# Altium schematic sheet ECU_MCU.SchDoc
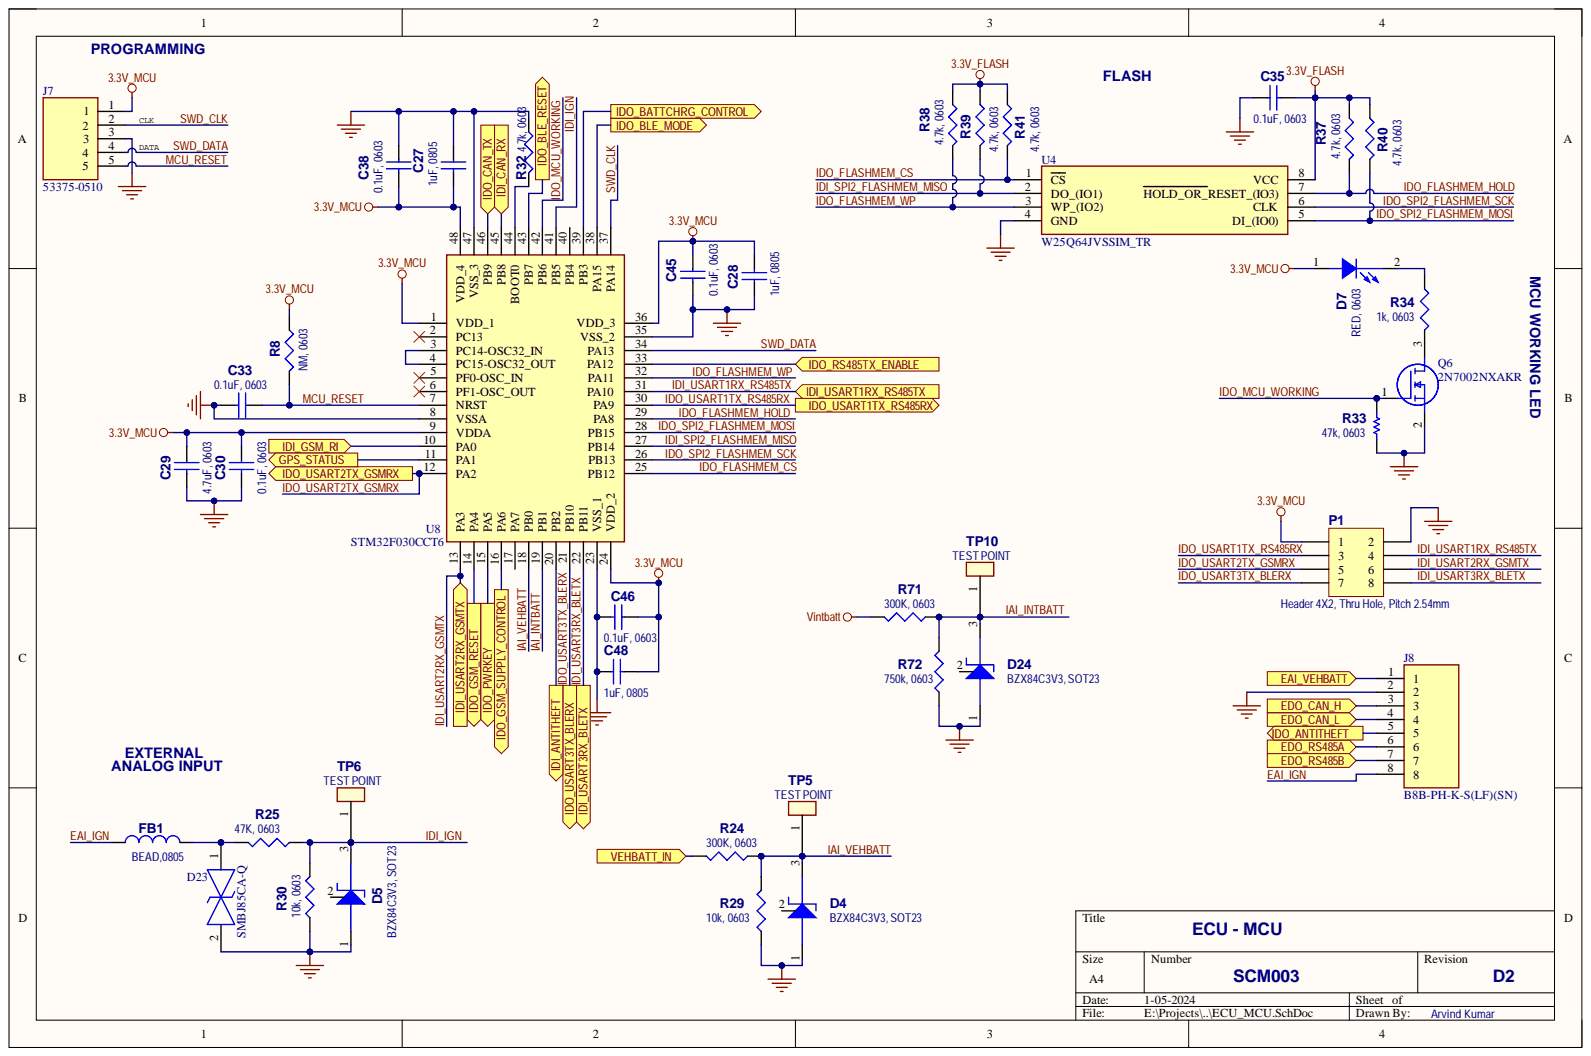
[diagram p0: ECU_MCU.SchDoc]

## Parts
C27  1uF, 0805  [C0805]
C28  1uF, 0805  [C0805]
C29  4.7uF, 0603  [C0603]
C30  0.1uF, 0603  [C0603]
C33  0.1uF, 0603  [C0603]
C35  0.1uF, 0603  [C0603]
C38  0.1uF, 0603  [C0603]
C45  0.1uF, 0603  [C0603]
C46  0.1uF, 0603  [C0603]
C48  1uF, 0805  [C0805]
D4  BZX84C3V3, SOT23  [BZX84C3V3-E3-08]
D5  BZX84C3V3, SOT23  [BZX84C3V3-E3-08]
D7  RED, 0603  [L0603]
D23  SMBJ85CA-Q  [DIONM5436X244N]
D24  BZX84C3V3, SOT23  [BZX84C3V3-E3-08]
FB1  BEAD,0805  [C0805]
J7  53375-0510  [53375-0510]
J8  B8B-PH-K-S(LF)(SN)  [SHDR8W50P0X200_1X8_1800X470X630P]
P1  Header 4X2, Thru Hole, Pitch 2.54mm  [HDR2X4]
Q6  2N7002NXAKR  [SOT95P230X110-3N]
R8  NM, 0603  [R0603]
R24  300K, 0603  [R0603]
R25  47K, 0603  [R0603]
R29  10k, 0603  [R0603]
R30  10k, 0603  [R0603]
R32  4.7k, 0603  [R0603]
R33  47k, 0603  [R0603]
R34  1k, 0603  [R0603]
R37  4.7k, 0603  [R0603]
R38  4.7k, 0603  [R0603]
R39  4.7k, 0603  [R0603]
R40  4.7k, 0603  [R0603]
R41  4.7k, 0603  [R0603]
R71  300K, 0603  [R0603]
R72  750k, 0603  [R0603]
TP5  TEST POINT  [Test Point SMD 1.5mm]
TP6  TEST POINT  [Test Point SMD 1.5mm]
TP10  TEST POINT  [Test Point SMD 1.5mm]
U4  W25Q64JVSSIM_TR  [SOIC127P790X216-8N]
U8  STM32F030CCT6  [QFP50P900X900X160-48N]

## Ports
EAI_VEHBATT, EDO_CAN_H, EDO_CAN_L, EDO_RS485A, EDO_RS485B, GPS_STATUS, IDI_ANTITHEFT, IDI_CAN_RX, IDI_GSM_RI, IDI_USART1RX_RS485TX, IDI_USART2RX_GSMTX, IDI_USART3RX_BLETX, IDO_ANTITHEFT, IDO_BATTCHRG_CONTROL, IDO_BLE_MODE, IDO_BLE_RESET, IDO_CAN_TX, IDO_GSM_RESET, IDO_GSM_SUPPLY_CONTROL, IDO_PWRKEY, IDO_RS485TX_ENABLE, IDO_USART1TX_RS485RX, IDO_USART2TX_GSMRX, IDO_USART3TX_BLERX, VEHBATT_IN

## Nets
3.3V_FLASH: C35.2 R37.2 R38.2 R39.2 R40.2 R41.2 U4.8(VCC)
3.3V_MCU: C27.2 C28.2 C29.1 C30.1 C38.2 C45.1 C46.1 C48.2 D7.1 J7.1 P1.1 R8.2 U8.1(VDD_1) U8.9(VDDA) U8.24(VDD_2) U8.36(VDD_3) U8.48(VDD_4)
GND_POWER: C27.1 C28.1 C29.2 C30.2 C33.2 C35.1 C38.1 C45.2 C46.2 C48.1 D4.1 D5.1 D23.2 D24.1 J7.3 J8.2 P1.2 Q6.2 R29.1 R30.1 R32.2 R33.1 R72.1 U4.4(GND) U8.8(VSSA) U8.23(VSS_1) U8.35(VSS_2) U8.47(VSS_3)
Vintbatt: R71.2
EAI_IGN: FB1.1 J8.8
EAI_VEHBATT: J8.1 ; port EAI_VEHBATT
EDO_CAN_H: J8.3 ; port EDO_CAN_H
EDO_CAN_L: J8.4 ; port EDO_CAN_L
EDO_RS485A: J8.6 ; port EDO_RS485A
EDO_RS485B: J8.7 ; port EDO_RS485B
GPS_STATUS: U8.11(PA1) ; port GPS_STATUS
IAI_INTBATT: D24.3 R71.1 R72.2 TP10.1 U8.19(PB1)
IAI_VEHBATT: D4.3 R24.1 R29.2 TP5.1 U8.18(PB0)
IDI_ANTITHEFT: U8.20(PB2) ; port IDI_ANTITHEFT
IDI_CAN_RX: U8.45(PB8) ; port IDI_CAN_RX
IDI_GSM_RI: U8.10(PA0) ; port IDI_GSM_RI
IDI_IGN: D5.3 R25.1 R30.2 TP6.1 U8.41(PB5)
IDI_SPI2_FLASHMEM_MISO: R39.1 U4.2(DO_(IO1)) U8.27(PB14)
IDI_USART1RX_RS485TX: P1.4 U8.31(PA10) ; port IDI_USART1RX_RS485TX
IDI_USART2RX_GSMTX: P1.6 U8.13(PA3) ; port IDI_USART2RX_GSMTX
IDI_USART3RX_BLETX: P1.8 U8.22(PB11) ; port IDI_USART3RX_BLETX
IDO_ANTITHEFT: J8.5 ; port IDO_ANTITHEFT
IDO_BATTCHRG_CONTROL: U8.39(PB3) ; port IDO_BATTCHRG_CONTROL
IDO_BLE_MODE: U8.38(PA15) ; port IDO_BLE_MODE
IDO_BLE_RESET: U8.43(PB7) ; port IDO_BLE_RESET
IDO_CAN_TX: U8.46(PB9) ; port IDO_CAN_TX
IDO_FLASHMEM_CS: R41.1 U4.1(CS) U8.25(PB12)
IDO_FLASHMEM_HOLD: R37.1 U4.7(HOLD_OR_RESET_(IO3)) U8.29(PA8)
IDO_FLASHMEM_WP: R38.1 U4.3(WP_(IO2)) U8.32(PA11)
IDO_GSM_RESET: U8.14(PA4) ; port IDO_GSM_RESET
IDO_GSM_SUPPLY_CONTROL: U8.16(PA6) ; port IDO_GSM_SUPPLY_CONTROL
IDO_MCU_WORKING: Q6.1 R33.2 U8.42(PB6)
IDO_PWRKEY: U8.15(PA5) ; port IDO_PWRKEY
IDO_RS485TX_ENABLE: U8.33(PA12) ; port IDO_RS485TX_ENABLE
IDO_SPI2_FLASHMEM_MOSI: R40.1 U4.5(DI_(IO0)) U8.28(PB15)
IDO_SPI2_FLASHMEM_SCK: U4.6(CLK) U8.26(PB13)
IDO_USART1TX_RS485RX: P1.3 U8.30(PA9) ; port IDO_USART1TX_RS485RX
IDO_USART2TX_GSMRX: P1.5 U8.12(PA2) ; port IDO_USART2TX_GSMRX
IDO_USART3TX_BLERX: P1.7 U8.21(PB10) ; port IDO_USART3TX_BLERX
MCU_RESET: C33.1 J7.5 R8.1 U8.7(NRST)
SWD_CLK: J7.2 U8.37(PA14)
SWD_DATA: J7.4 U8.34(PA13)
VEHBATT_IN: R24.2 ; port VEHBATT_IN
NetD7_2: D7.2 R34.1
NetD23_1: D23.1 FB1.2 R25.2
NetQ6_3: Q6.3 R34.2
NetR32_1: R32.1 U8.44(BOOT0)
NetU8_3: U8.3(PC14-OSC32_IN) U8.4(PC15-OSC32_OUT)

Not connected: D4 2; D5 2; D24 2; U8 2(PC13) 5(PF0-OSC_IN) 6(PF1-OSC_OUT) 17(PA7) 40(PB4)

## Library data of the parts
C27-C30 C48 = CAP NP: Component Kind: Standard
C33 C35 C38 C45 C46 = CAP NP_Dup2: Component Kind: Standard
D4 D5 D24 = DIODE ZENER_3: Component Kind: Standard
D7 = LED_Dup1: Component Kind: Standard
D23 = SMBJ85CA-Q: TVS Diode (Bi-directional); Manufacturer_Name: Bourns; Component Kind: Standard; Datasheet Link: https://www.bourns.com/docs/Product-Datasheets/SMBJ-Q.pdf; Height: 2.44mm; Manufacturer_Part_Number: SMBJ85CA-Q
FB1 = INDUCTOR: Component Kind: Standard
J7 = 53375-0510: Connector; Manufacturer_Name: Molex; Component Kind: Standard; Datasheet Link: http://uk.rs-online.com/web/p/products/7206404; Manufacturer_Part_Number: 53375-0510; Mouser Part Number: 538-53375-0510; Mouser Price/Stock: https://www.mouser.co.uk/ProductDetail/Molex/53375-0510?qs=sC9oT6LG9NA43%2Fz76%252BfNAQ%3D%3D
J8 = 62000811622: Connector; Manufacturer_Name: Wurth Elektronik; Component Kind: Standard; Datasheet Link: https://www.we-online.com/catalog/datasheet/62000811622.pdf; Height: 6.3mm; Manufacturer_Part_Number: 62000811622
P1 = Header 4X2: Header, 4-Pin, Dual row; Component Kind: Standard; LatestRevisionDate: 17-Jul-2002; LatestRevisionNote: Re-released for DXP Platform.; Library Name: Miscellaneous Connectors.IntLib; Signal Integrity: Connector; Publisher: Altium Limited
Q6 = 2N7002NXAKR: MOSFET (N-Channel); Manufacturer_Name: Nexperia; Arrow Part Number: 2N7002NXAKR; Arrow Price/Stock: https://www.arrow.com/en/products/2n7002nxakr/nexperia?region=asia; Component Kind: Standard; Datasheet Link: https://assets.nexperia.com/documents/data-sheet/2N7002NXAK.pdf; Height: 1.1mm; Manufacturer_Part_Number: 2N7002NXAKR; Mouser Part Number: 771-2N7002NXAKR; Mouser Price/Stock: https://www.mouser.co.uk/ProductDetail/Nexperia/2N7002NXAKR?qs=%252B6g0mu59x7JoAsZYPFXqhw%3D%3D
R8 R32 R37-R41 = R: Component Kind: Standard
R24 R25 R29 R30 R71 R72 = R_Dup1: Component Kind: Standard
R33 = Res1: Resistor; Component Kind: Standard; LatestRevisionDate: 17-Jul-2002; LatestRevisionNote: Re-released for DXP Platform.; Library Name: Miscellaneous Devices.IntLib; PackageDescription: Axial Device, Thru-Hole; 2 Leads; 0.3 in Pin Spacing; PackageReference: AXIAL-0.3; Published: 8-Jun-2000; Signal Integrity: Res; Simulation: RESISTOR; Value: 47k; Publisher: Altium Limited
R34 = RESISTOR: Component Kind: Standard
U4 = W25Q64JVSSIM_TR: Integrated Circuit; Manufacturer_Name: Winbond; Manufacturer_Part_Number: W25Q64JVSSIM TR; Arrow Part Number: W25Q64JVSSIM TR; Arrow Price/Stock: https://www.arrow.com/en/products/w25q64jvssimtr/winbond-electronics?region=nac; Component Kind: Standard; Datasheet Link: http://www.winbond.com/resource-files/w25q64jv%20dtr%20revf%2012212016.pdf; Height: 2.16mm; Mouser Part Number: 454-W25Q64JVSSIMTR; Mouser Price/Stock: https://www.mouser.co.uk/ProductDetail/Winbond/W25Q64JVSSIM-TR?qs=qSfuJ%252Bfl%2Fd4D4t%252BHFzDXrw%3D%3D
U8 = STM32F030CCT6: Integrated Circuit; Manufacturer_Name: STMicroelectronics; Arrow Part Number: STM32F030CCT6; Arrow Price/Stock: https://www.arrow.com/en/products/stm32f030cct6/stmicroelectronics; Component Kind: Standard; Datasheet Link: http://www.st.com/content/ccc/resource/technical/document/datasheet/a4/5d/0b/0e/87/c4/4d/71/DM00088500.pdf/files/DM00088500.pdf/jcr:content/translations/en.DM00088500.pdf; Height: 1.6mm; Manufacturer_Part_Number: STM32F030CCT6; Mouser Part Number: 511-STM32F030CCT6; Mouser Price/Stock: https://www.mouser.co.uk/ProductDetail/STMicroelectronics/STM32F030CCT6?qs=P9Xy8ZBwTyt0TDNcEmCzmQ%3D%3D
TP5 TP6 TP10 = TEST POINT: Component Kind: Standard
